# Altium schematic sheet top.SchDoc
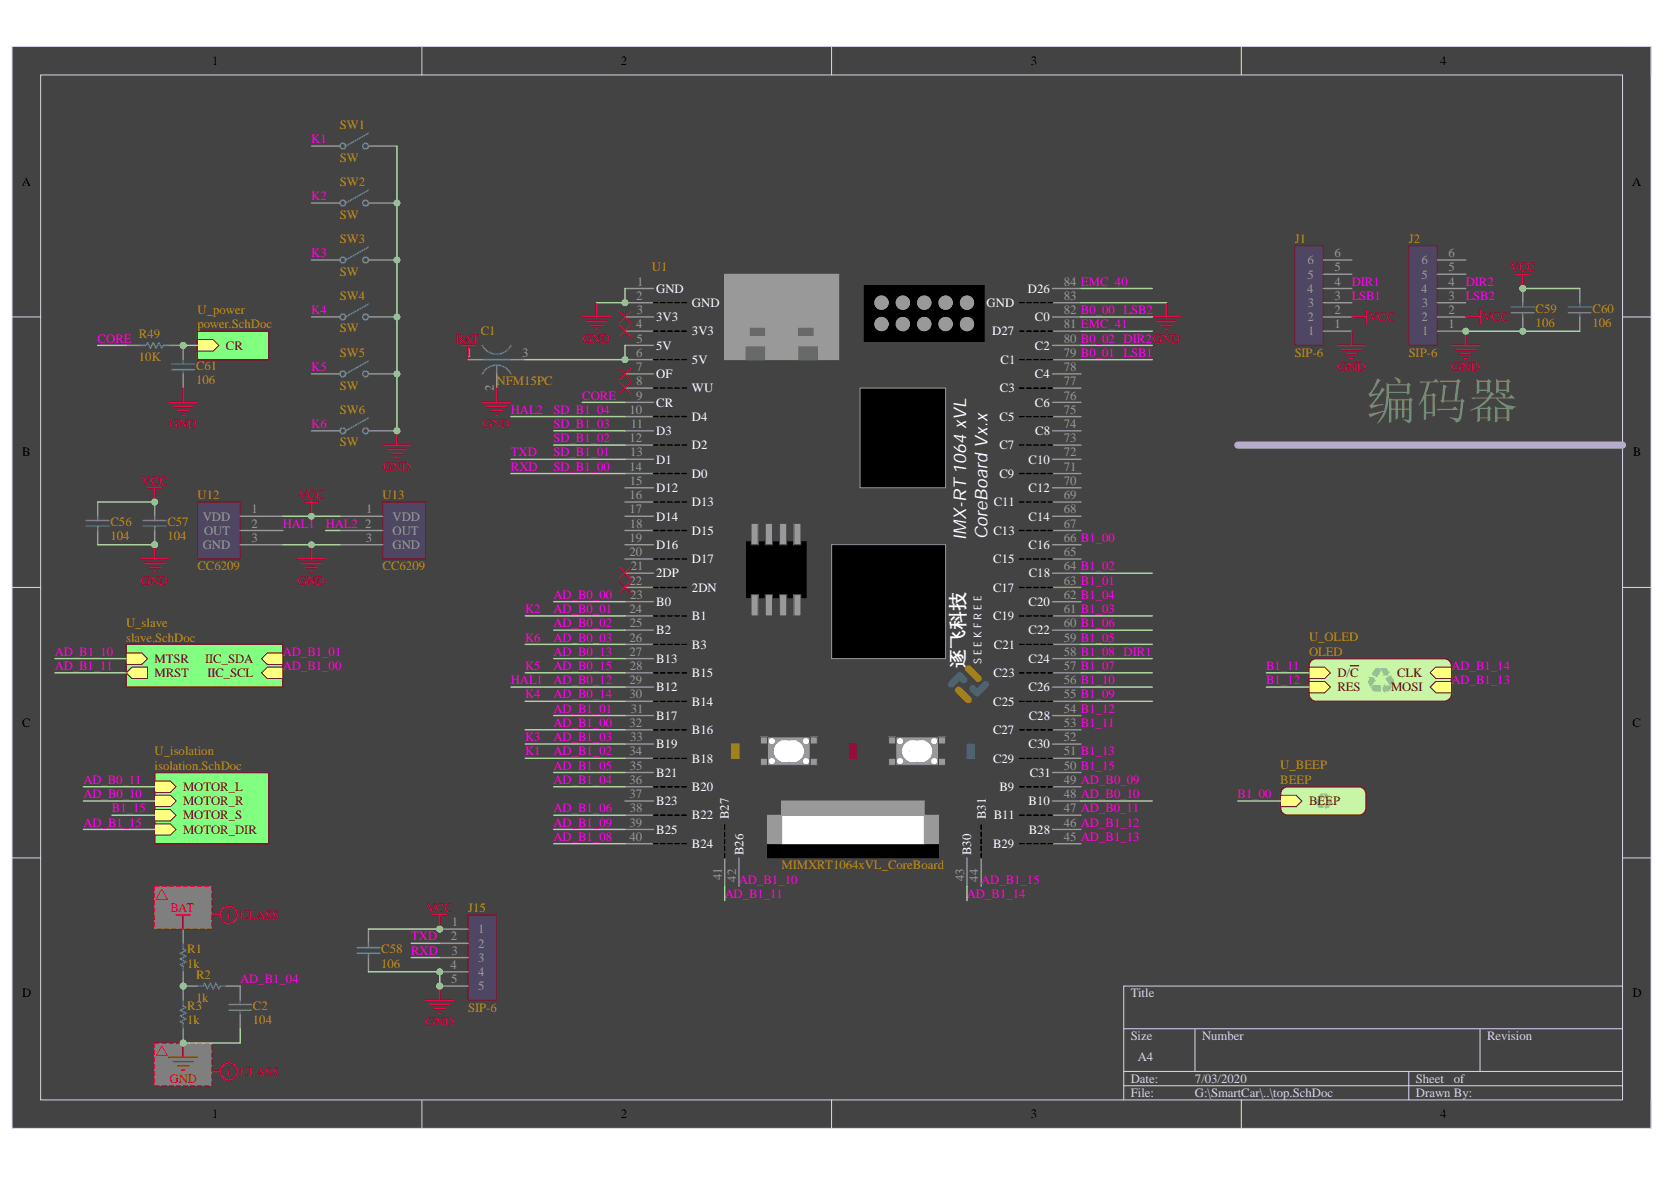
[diagram p0: top.SchDoc]

## Parts
C1  NFM15PC  [FILTER-SMD_3P-L1.0-W0.5-L]
C2  104  [C0603]
C56  104  [C0603]
C57  104  [C0603]
C58  106  [C0603]
C59  106  [C0603]
C60  106  [C0603]
C61  106  [C0603]
J1  SIP-6  [2.54简易牛角座6P]
J2  SIP-6  [2.54简易牛角座6P]
J15  SIP-6  [2.54排针1X5]
R1  1k  [R0603]
R2  1k  [R0603]
R3  1k  [R0603]
R49  10K  [R0603]
SW1  SW  [KEY-SMD_4P-L4.2-W3.2-P2.20-LS4.6]
SW2  SW  [KEY-SMD_4P-L4.2-W3.2-P2.20-LS4.6]
SW3  SW  [KEY-SMD_4P-L4.2-W3.2-P2.20-LS4.6]
SW4  SW  [KEY-SMD_4P-L4.2-W3.2-P2.20-LS4.6]
SW5  SW  [KEY-SMD_4P-L4.2-W3.2-P2.20-LS4.6]
SW6  SW  [KEY-SMD_4P-L4.2-W3.2-P2.20-LS4.6]
U1  MIMXRT1064xVL_CoreBoard  [MIMXRT1064xVL_CoreBoard]
U12  CC6209  [SOT-23]
U13  CC6209  [SOT-23]

## Nets
BAT: C1.1 R1.2
GND: C1.2 C2.1 C56.1 C57.1 C58.1 C59.1 C60.1 C61.1 J1.1 J2.1 J15.4 J15.5 R3.1 SW1.2 SW2.2 SW3.2 SW4.2 SW5.2 SW6.2 U1.1(GND) U1.2 U1.83 U12.3 U13.3
VCC: C56.2 C57.2 C58.2 C59.2 C60.2 J1.2 J2.2 J15.1 U12.1 U13.1
AD_B0_00: U1.23(B0)
AD_B0_01: SW2.1 U1.24
AD_B0_02: U1.25(B2)
AD_B0_03: SW6.1 U1.26
AD_B0_09: U1.49
AD_B0_10: U1.48(B10)
AD_B0_11: U1.47
AD_B0_12: U1.29(B12) U12.2
AD_B0_13: U1.27(B13)
AD_B0_14: SW4.1 U1.30
AD_B0_15: SW5.1 U1.28
AD_B1_00: U1.32
AD_B1_01: U1.31(B17)
AD_B1_02: SW1.1 U1.34
AD_B1_03: SW3.1 U1.33(B19)
AD_B1_04: C2.2 R2.1 U1.36
AD_B1_05: U1.35(B21)
AD_B1_06: U1.38
AD_B1_08: U1.40
AD_B1_09: U1.39(B25)
AD_B1_10: U1.42(B26)
AD_B1_11: U1.41
AD_B1_12: U1.46(B28)
AD_B1_13: U1.45
AD_B1_14: U1.43(B30)
AD_B1_15: U1.44
B0_00: J2.3 U1.82(C0)
B0_01: J1.3 U1.79
B0_02: J2.4 U1.80(C2)
B1_00: U1.66(C16)
B1_01: U1.63
B1_02: U1.64(C18)
B1_03: U1.61
B1_04: U1.62(C20)
B1_05: U1.59
B1_06: U1.60(C22)
B1_07: U1.57
B1_08: J1.4 U1.58(C24)
B1_09: U1.55
B1_10: U1.56(C26)
B1_11: U1.53
B1_12: U1.54(C28)
B1_13: U1.51
B1_15: U1.50(C31)
CORE: R49.2 U1.9(CR)
EMC_40: U1.84(D26)
EMC_41: U1.81
HAL2: U1.10 U13.2
RXD: J15.3 U1.14
SD_B1_01: J15.2 U1.13(D1)
SD_B1_02: U1.12
SD_B1_03: U1.11(D3)
NetC1_3: C1.3 U1.5(5V) U1.6
NetC61_2: C61.2 R49.1
NetJ1_5: J1.5
NetJ1_6: J1.6
NetJ2_5: J2.5
NetJ2_6: J2.6
NetR1_1: R1.1 R2.2 R3.2
NetU1_3: U1.3(3V3)
NetU1_4: U1.4
NetU1_7: U1.7(OF)
NetU1_8: U1.8
NetU1_15: U1.15(D12)
NetU1_16: U1.16
NetU1_17: U1.17(D14)
NetU1_18: U1.18
NetU1_19: U1.19(D16)
NetU1_20: U1.20
NetU1_21: U1.21(2DP)
NetU1_22: U1.22
NetU1_37: U1.37(B23)
NetU1_52: U1.52(C30)
NetU1_65: U1.65
NetU1_67: U1.67
NetU1_68: U1.68(C14)
NetU1_69: U1.69
NetU1_70: U1.70(C12)
NetU1_71: U1.71
NetU1_72: U1.72(C10)
NetU1_73: U1.73
NetU1_74: U1.74(C8)
NetU1_75: U1.75
NetU1_76: U1.76(C6)
NetU1_77: U1.77
NetU1_78: U1.78(C4)

## Library data of the parts
C1 = 陶瓷滤波器: BOM_Manufacturer: Murata Electronics; BOM_Manufacturer Part: NFM15PC473C1C3D; Capacitance: 47nF; Contributor: LCSC; BOM_Supplier: LCSC; BOM_Supplier Part: C162672; Component Kind: Standard; Library Name: iMag.IntLib; spicePre: U; spiceSymbolName: NFM15PC473C1C3D
C2 C56-C61 = 电容: Capacitor; Value: 22pF; Component Kind: Standard; LatestRevisionDate: 17-Jul-2002; LatestRevisionNote: Re-released for DXP Platform.; Library Name: iMag.IntLib; PackageDescription: Radial Cap, Thru-Hole; 2 Leads; 0.3 in Pin Spacing; PackageReference: RAD-0.3; Published: 8-Jun-2000; Publisher: Altium Limited
J15 = SIP-5: Component Kind: Standard; Library Name: iMag.IntLib
R1-R3 R49 = 电阻: Resistor; Value: 150R; Component Kind: Standard; LatestRevisionDate: 17-Jul-2002; LatestRevisionNote: Re-released for DXP Platform.; Library Name: iMag.IntLib; PackageDescription: Axial Device, Thru-Hole; 2 Leads; 0.3 in Pin Spacing; PackageReference: AXIAL-0.3; Published: 8-Jun-2000; Publisher: Altium Limited
SW1-SW6 = Switch: Single-Pole, Single-Throw Switch; Component Kind: Standard; Library Name: iMag.IntLib
U1 = MIMXRT1064xVL_CoreBoard: 逐飞科技i.MX RT1064核心板整体原理，可直接使用; Component Kind: Standard; Library Name: iMag.IntLib
U12 U13 = 霍尔开关: Component Kind: Standard; Library Name: iMag.IntLib
J1 J2 = SIP-6: Component Kind: Standard; Library Name: iMag.IntLib
